# Altium schematic sheet FPGA_Main.SchDoc(FPGA_Main)
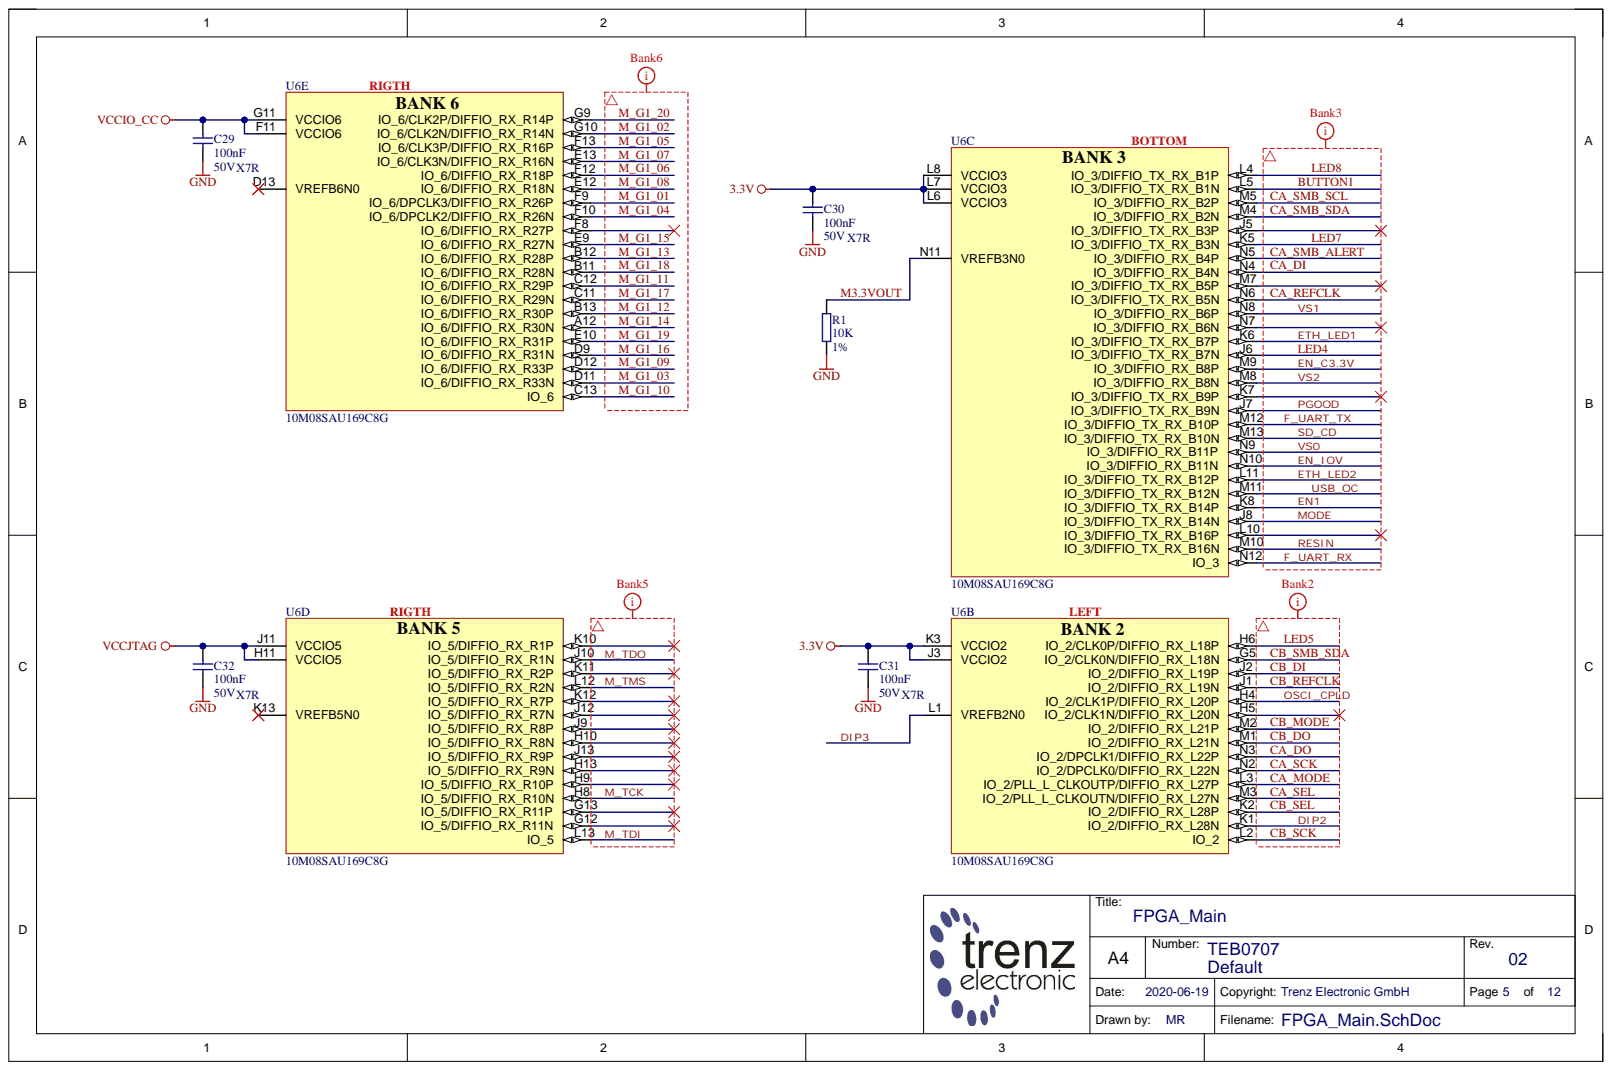
[diagram p0: FPGA_Main.SchDoc]

## Parts
C29  100nF  Voltage: 50V  [CAPC1005X55N]
C30  100nF  Voltage: 50V  [CAPC1005X55N]
C31  100nF  Voltage: 50V  [CAPC1005X55N]
C32  100nF  Voltage: 50V  [CAPC1005X55N]
R1  10K  Tolerance: 1%  [RESC1005X40N]
U6  10M08SAU169C8G  [BGA169C80P13X13_11X11X15]  (parts B,C,D,E)

## Nets
3.3V: C30.1 C31.1 U6.J3(VCCIO2) U6.K3(VCCIO2) U6.L6(VCCIO3) U6.L7(VCCIO3) U6.L8(VCCIO3)
GND: C29.2 C30.2 C31.2 C32.2 R1.1
VCCIO_CC: C29.1 U6.F11(VCCIO6) U6.G11(VCCIO6)
VCCJTAG: C32.1 U6.H11(VCCIO5) U6.J11(VCCIO5)
BUTTON1: U6.L5(IO_3/DIFFIO_TX_RX_B1N)
CA_DI: U6.N4(IO_3/DIFFIO_RX_B4N)
CA_DO: U6.N3(IO_2/DPCLK1/DIFFIO_RX_L22P)
CA_MODE: U6.L3(IO_2/PLL_L_CLKOUTP/DIFFIO_RX_L27P)
CA_REFCLK: U6.N6(IO_3/DIFFIO_TX_RX_B5N)
CA_SCK: U6.N2(IO_2/DPCLK0/DIFFIO_RX_L22N)
CA_SEL: U6.M3(IO_2/PLL_L_CLKOUTN/DIFFIO_RX_L27N)
CA_SMB_ALERT: U6.N5(IO_3/DIFFIO_RX_B4P)
CA_SMB_SCL: U6.M5(IO_3/DIFFIO_RX_B2P)
CA_SMB_SDA: U6.M4(IO_3/DIFFIO_RX_B2N)
CB_DI: U6.J2(IO_2/DIFFIO_RX_L19P)
CB_DO: U6.M1(IO_2/DIFFIO_RX_L21N)
CB_MODE: U6.M2(IO_2/DIFFIO_RX_L21P)
CB_REFCLK: U6.J1(IO_2/DIFFIO_RX_L19N)
CB_SCK: U6.L2(IO_2)
CB_SEL: U6.K2(IO_2/DIFFIO_RX_L28P)
CB_SMB_SDA: U6.G5(IO_2/CLK0N/DIFFIO_RX_L18N)
DIP2: U6.K1(IO_2/DIFFIO_RX_L28N)
DIP3: U6.L1(VREFB2N0)
EN1: U6.K8(IO_3/DIFFIO_TX_RX_B14P)
EN_C3.3V: U6.M9(IO_3/DIFFIO_RX_B8P)
EN_IOV: U6.N10(IO_3/DIFFIO_RX_B11N)
ETH_LED1: U6.K6(IO_3/DIFFIO_TX_RX_B7P)
ETH_LED2: U6.L11(IO_3/DIFFIO_TX_RX_B12P)
F_UART_RX: U6.N12(IO_3)
F_UART_TX: U6.M12(IO_3/DIFFIO_TX_RX_B10P)
LED4: U6.J6(IO_3/DIFFIO_TX_RX_B7N)
LED5: U6.H6(IO_2/CLK0P/DIFFIO_RX_L18P)
LED7: U6.K5(IO_3/DIFFIO_TX_RX_B3N)
LED8: U6.L4(IO_3/DIFFIO_TX_RX_B1P)
M3.3VOUT: R1.2 U6.N11(VREFB3N0)
M_G1_01: U6.F9(IO_6/DPCLK3/DIFFIO_RX_R26P)
M_G1_02: U6.G10(IO_6/CLK2N/DIFFIO_RX_R14N)
M_G1_03: U6.D11(IO_6/DIFFIO_RX_R33N)
M_G1_04: U6.F10(IO_6/DPCLK2/DIFFIO_RX_R26N)
M_G1_05: U6.F13(IO_6/CLK3P/DIFFIO_RX_R16P)
M_G1_06: U6.F12(IO_6/DIFFIO_RX_R18P)
M_G1_07: U6.E13(IO_6/CLK3N/DIFFIO_RX_R16N)
M_G1_08: U6.E12(IO_6/DIFFIO_RX_R18N)
M_G1_09: U6.D12(IO_6/DIFFIO_RX_R33P)
M_G1_10: U6.C13(IO_6)
M_G1_11: U6.C12(IO_6/DIFFIO_RX_R29P)
M_G1_12: U6.B13(IO_6/DIFFIO_RX_R30P)
M_G1_13: U6.B12(IO_6/DIFFIO_RX_R28P)
M_G1_14: U6.A12(IO_6/DIFFIO_RX_R30N)
M_G1_15: U6.E9(IO_6/DIFFIO_RX_R27N)
M_G1_16: U6.D9(IO_6/DIFFIO_RX_R31N)
M_G1_17: U6.C11(IO_6/DIFFIO_RX_R29N)
M_G1_18: U6.B11(IO_6/DIFFIO_RX_R28N)
M_G1_19: U6.E10(IO_6/DIFFIO_RX_R31P)
M_G1_20: U6.G9(IO_6/CLK2P/DIFFIO_RX_R14P)
M_TCK: U6.H8(IO_5/DIFFIO_RX_R10N)
M_TDI: U6.L13(IO_5)
M_TDO: U6.J10(IO_5/DIFFIO_RX_R1N)
M_TMS: U6.L12(IO_5/DIFFIO_RX_R2N)
MODE: U6.J8(IO_3/DIFFIO_TX_RX_B14N)
OSCI_CPLD: U6.H4(IO_2/CLK1P/DIFFIO_RX_L20P)
PGOOD: U6.J7(IO_3/DIFFIO_TX_RX_B9N)
RESIN: U6.M10(IO_3/DIFFIO_TX_RX_B16N)
SD_CD: U6.M13(IO_3/DIFFIO_TX_RX_B10N)
USB_OC: U6.M11(IO_3/DIFFIO_TX_RX_B12N)
VS0: U6.N9(IO_3/DIFFIO_RX_B11P)
VS1: U6.N8(IO_3/DIFFIO_RX_B6P)
VS2: U6.M8(IO_3/DIFFIO_RX_B8N)

Not connected: U6 D13(VREFB6N0) F8(IO_6/DIFFIO_RX_R27P) G12(IO_5/DIFFIO_RX_R11N) G13(IO_5/DIFFIO_RX_R11P) H5(IO_2/CLK1N/DIFFIO_RX_L20N) H9(IO_5/DIFFIO_RX_R10P) H10(IO_5/DIFFIO_RX_R8N) H13(IO_5/DIFFIO_RX_R9N) J5(IO_3/DIFFIO_TX_RX_B3P) J9(IO_5/DIFFIO_RX_R8P) J12(IO_5/DIFFIO_RX_R7N) J13(IO_5/DIFFIO_RX_R9P) K7(IO_3/DIFFIO_TX_RX_B9P) K10(IO_5/DIFFIO_RX_R1P) K11(IO_5/DIFFIO_RX_R2P) K12(IO_5/DIFFIO_RX_R7P) K13(VREFB5N0) L10(IO_3/DIFFIO_TX_RX_B16P) M7(IO_3/DIFFIO_TX_RX_B5P) N7(IO_3/DIFFIO_RX_B6N)

## Library data of the parts
C29-C32 = 22651: KON 100nF 50V X7R ±10% SMD BF 1005 (0402); Database Table Name: C_1005_0402; Mixed: SMT; Temperature-Range: -55/+125; Value: 100n; AN: 22651; Case: 1005 (0402); Component Kind: Standard; Library Name: TE.DbLib; Technology: X7R; Tolerance: 10%
R1 = 22750: WID SMD 10K 1% 0,063W BF 1005 (0402); Database Table Name: R_1005_0402; H-ART: RC0402FR-0710KL; Mixed: SMT; Preferred: Yes; AN: 22750; Case: 1005 (0402); Component Kind: Standard; Library Name: TE.DbLib; Technology: TK100; Value: 10K
U6 = 27930: Database Table Name: PROG_LOGIC; Extra: Altera MAX10 FPGA 8k 130 I/O; Mixed: SMT; Temperature-Range: 0/+85 (Tj); AN: 27930; Case: LFBGA169; Component Kind: Standard; Description: 10M08SAU169C8G; H-ART: 10M08SAU169C8G; Library Name: TE.DbLib; Storage-Temperature: -65/+150(Abs); HelpURL: b:\AD\adlib\datasheet\ALTERA_MAX10\
